# Altium schematic sheet [06] - USB_HOST.SchDoc
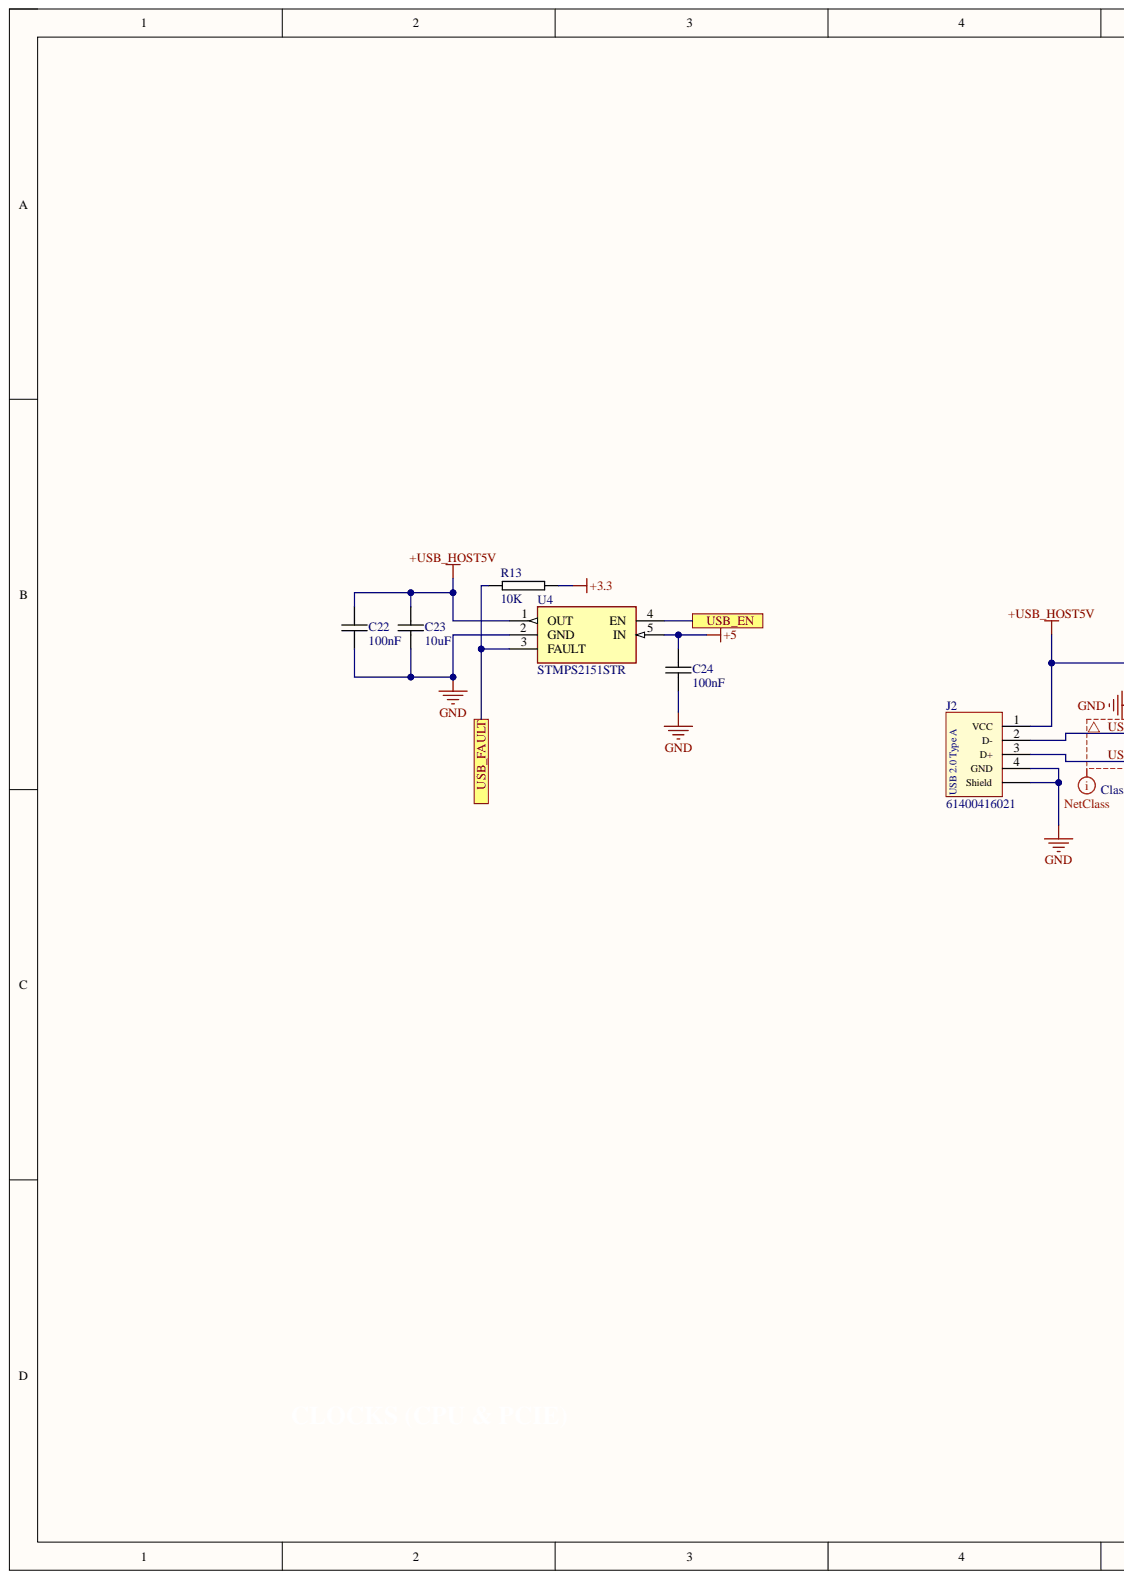
[diagram p0: [06] - USB_HOST.SchDoc, left]
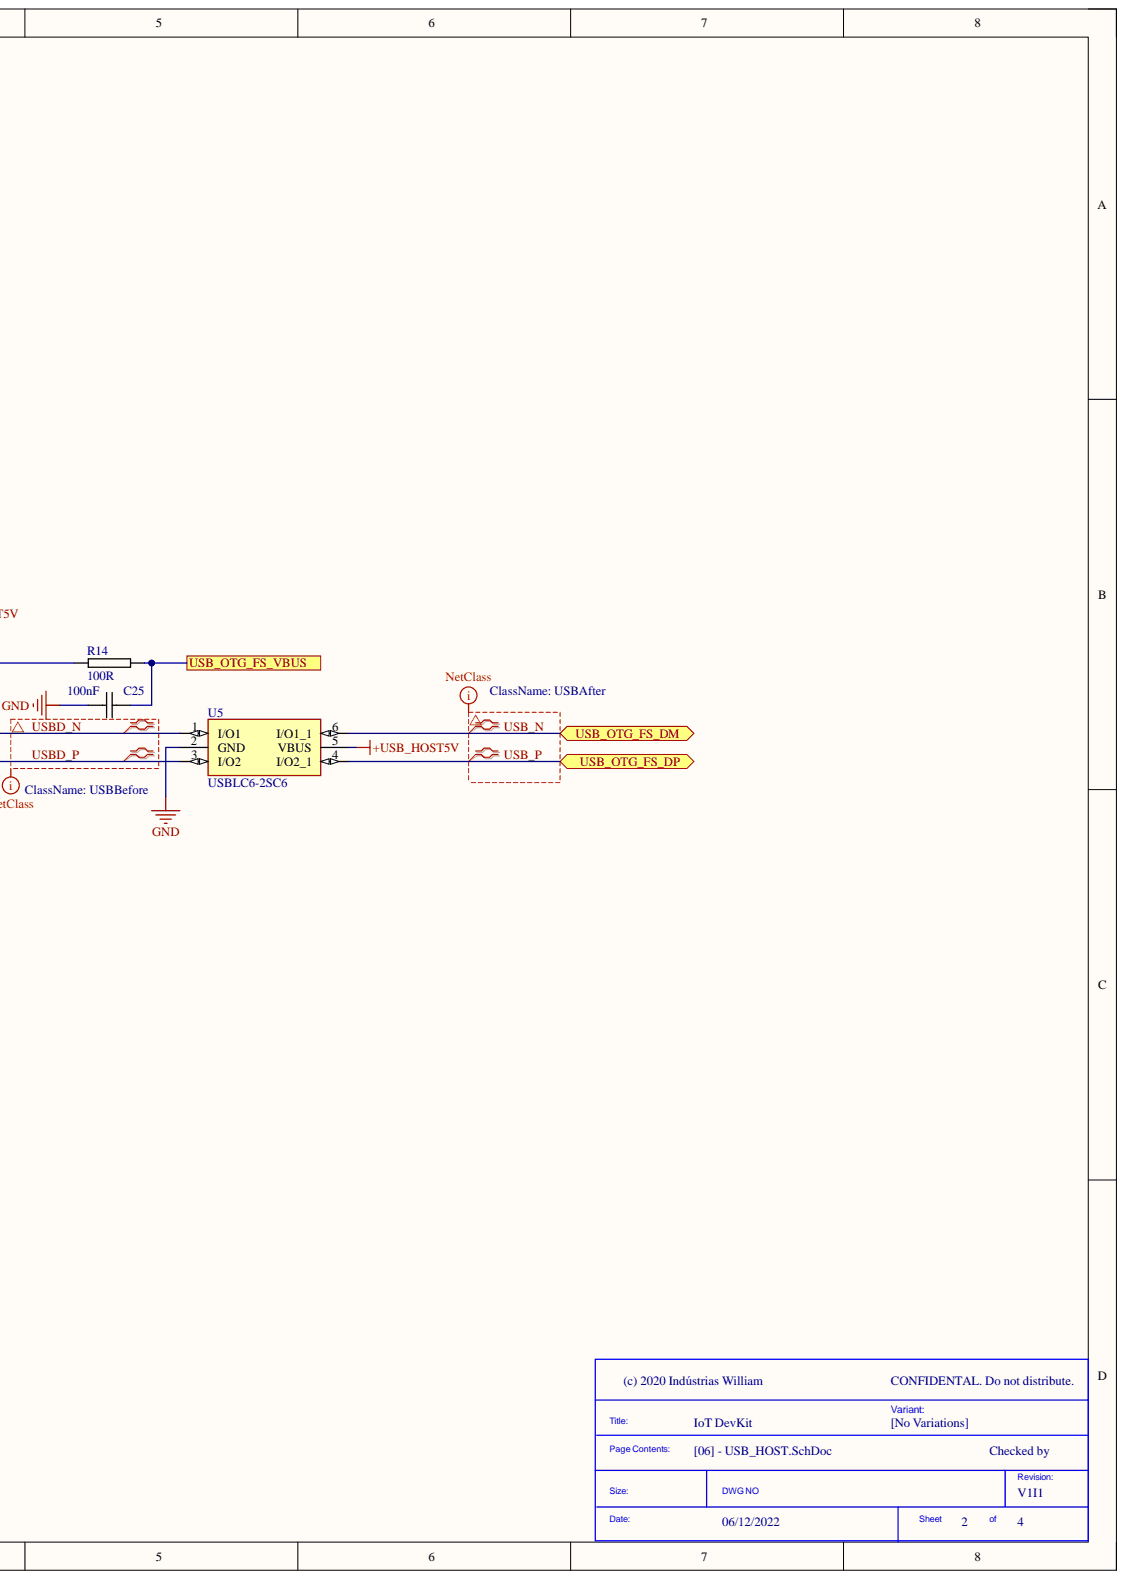
[diagram p1: [06] - USB_HOST.SchDoc, right]

## Parts
C22  100nF  [0603C-GENERIC]
C23  10uF  [0603C-GENERIC]
C24  100nF  [0603C-GENERIC]
C25  100nF  [0603C-GENERIC]
J2  61400416021  Interface Type: Type A  Sub-Family: USB 2.0  [61400416021]
R13  10K  [0603R-10K]
R14  100R  [0603R-100]
U4  STMPS2151STR  [SOT95P280X145-5N]
U5  USBLC6-2SC6  [SOT95P280X145-6N]

## Ports
USB_EN, USB_FAULT, USB_OTG_FS_DM, USB_OTG_FS_DP, USB_OTG_FS_VBUS

## Nets
+3.3: R13.2
+5: C24.1 U4.5(IN)
+USB_HOST5V: C22.1 C23.1 J2.1(VCC) R14.1 U4.1(OUT) U5.5(VBUS)
GND: C22.2 C23.2 C24.2 C25.2 J2.4(GND) J2.Shell(Shield) U4.2(GND) U5.2(GND)
USB_EN: U4.4(EN) ; port USB_EN
USB_FAULT: R13.1 U4.3(FAULT) ; port USB_FAULT
USB_N: U5.6(I/O1_1) ; port USB_OTG_FS_DM
USB_OTG_FS_VBUS: C25.1 R14.2 ; port USB_OTG_FS_VBUS
USB_P: U5.4(I/O2_1) ; port USB_OTG_FS_DP
USBD_N: J2.2(D-) U5.1(I/O1)
USBD_P: J2.3(D+) U5.3(I/O2)

## Library data of the parts
C22 C24 C25 = 0603C-100NF-25V-X7R-TDK: CAPACITOR 0603 CERAMIC; Manufacturer: TDK Corporation; Manufacturer Part Number: C1608X7R1E104K; Reel: C12; Component Kind: Standard; Library Name: vh_altium_library.intLib; Supplier 1: Digi-Key; Supplier Part Number 1: 445-1316-2-ND
C23 = 0603C-10UF-10V-X5R-TDK: CAPACITOR 0603 CERAMIC; Manufacturer: TDK Corporation; Manufacturer Part Number: C1608X5R0J106M; Reel: C31; Component Kind: Standard; Library Name: vh_altium_library.intLib; Supplier 1: Digi-Key; Supplier Part Number 1: 445-4112-2-ND
J2 = 61400416021: USB 2.0 Type A Horizontal WR-COM; Gender: Receptacle; Component Kind: Standard; ComponentLink1Description: Manufacturer; ComponentLink1URL: http://www.we-online.de; ComponentLink2Description: Datasheet; ComponentLink2URL: http://katalog.we-online.de/em/datasheet/61400416021.pdf; Construction: THT; Library Name: Altium_WR-COM (rev17a).IntLib; Part Number: 61400416021; Published Date: April 2017; Type: Horizontal; Publisher: Wurth Elektronik
R13 = 0603R-10K: GENERIC RESISTOR 0603; Manufacturer: Stackpole Electronics Inc; Manufacturer Part Number: RMCF 1/16 10K 1% R; Power (Watts): 0.1W, 1/10W; Reel: R21; Resistance In Ohms: 10.0K; Temperature Coefficient: ±100ppm/°C; Tolerance: ±1%; Case: 0603 (1608 metric); Category: Resistors; Component Kind: Standard; Composition: Thick Film; Lead Style: Surface Mount (SMD - SMT); Library Name: vh_altium_library.intLib; Packaging: Digi-Reel®; RoHS: RoHS Compliant; Supplier: Digi-Key; Supplier 1: Digi-Key; Supplier Part Number: RMCF1/1610KFRDKR-ND; Supplier Part Number 1: RMCF0603FT10K0DKR-ND
R14 = 0603R-100: GENERIC RESISTOR 0603; Manufacturer: Stackpole Electronics Inc; Manufacturer Part Number: RMCF 1/16 100 1% R; Reel: R24; Component Kind: Standard; Library Name: vh_altium_library.intLib; Supplier 1: Digi-Key; Supplier Part Number 1: RMCF1/16100FRDKR-ND
U4 = STMPS2151STR: Enhanced single channel power switches; Manufacturer_Name: STMicroelectronics; Component Kind: Standard; Datasheet Link: http://docs-emea.rs-online.com/webdocs/140d/0900766b8140da90.pdf; Height: 1.45mm; Manufacturer_Part_Number: STMPS2151STR; Mouser Part Number: 511-STMPS2151STR; Mouser Price/Stock: https://www.mouser.com/Search/Refine.aspx?Keyword=511-STMPS2151STR; RS Part Number: 8805415P; RS Price/Stock: http://uk.rs-online.com/web/p/products/8805415P; Library Name: Componentes Deived Projetos.IntLib
U5 = USBLC6-2SC6: Very low capacitance ESD protection; Manufacturer_Name: STMicroelectronics; Allied_Number: 70389689; Allied Price/Stock: http://www.alliedelec.com/stmicroelectronics-usblc6-2sc6/70389689/; Component Kind: Standard; Datasheet Link: http://www.st.com/st-web-ui/static/active/en/resource/technical/document/datasheet/CD00050750.pdf; Height: 1.45mm; Manufacturer_Part_Number: USBLC6-2SC6; Mouser Part Number: 511-USBLC6-2SC6; Mouser Price/Stock: https://www.mouser.co.uk/ProductDetail/STMicroelectronics/USBLC6-2SC6?qs=po45yt2pPpu%2FhNIlwQdTlg%3D%3D; RS Part Number: 6247693P; RS Price/Stock: http://uk.rs-online.com/web/p/products/6247693P; Library Name: Componentes Deived Projetos.IntLib
